# Altium schematic sheet P770010E05-Xx-SH-3_LNA.SchDoc(P770010E05-Xx-SH-3_LNA)
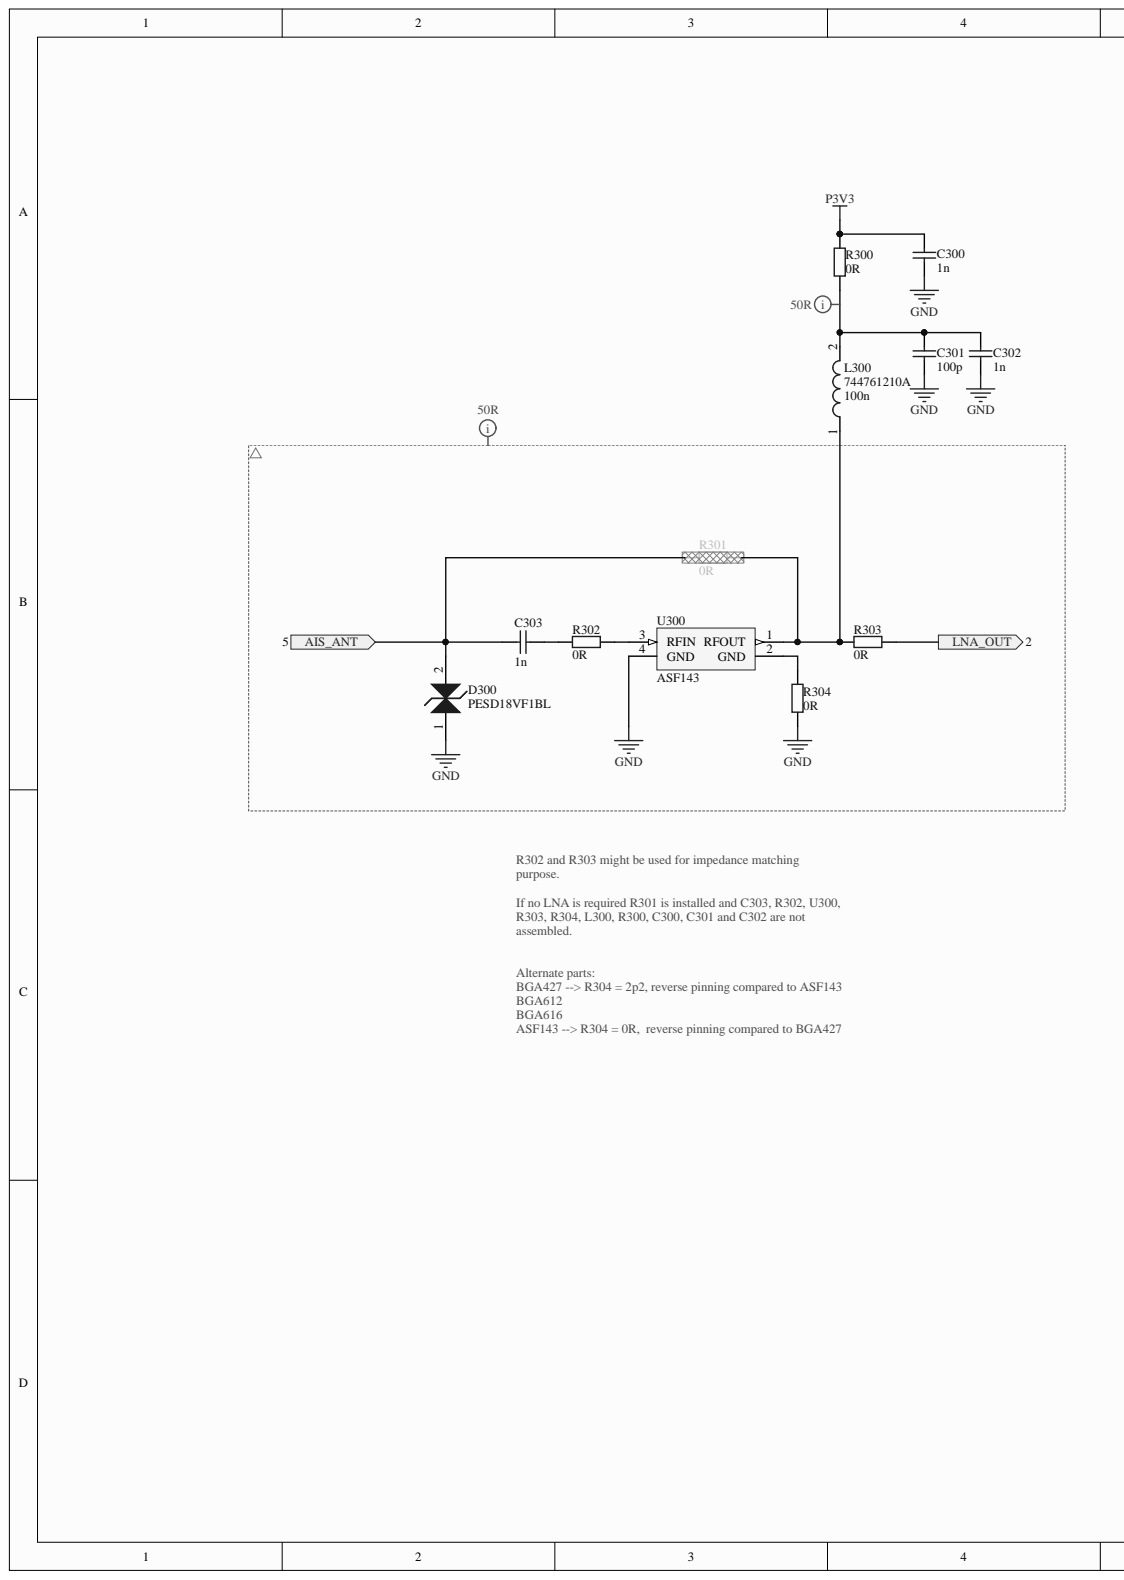
[diagram p0: P770010E05-Xx-SH-3_LNA.SchDoc, left]
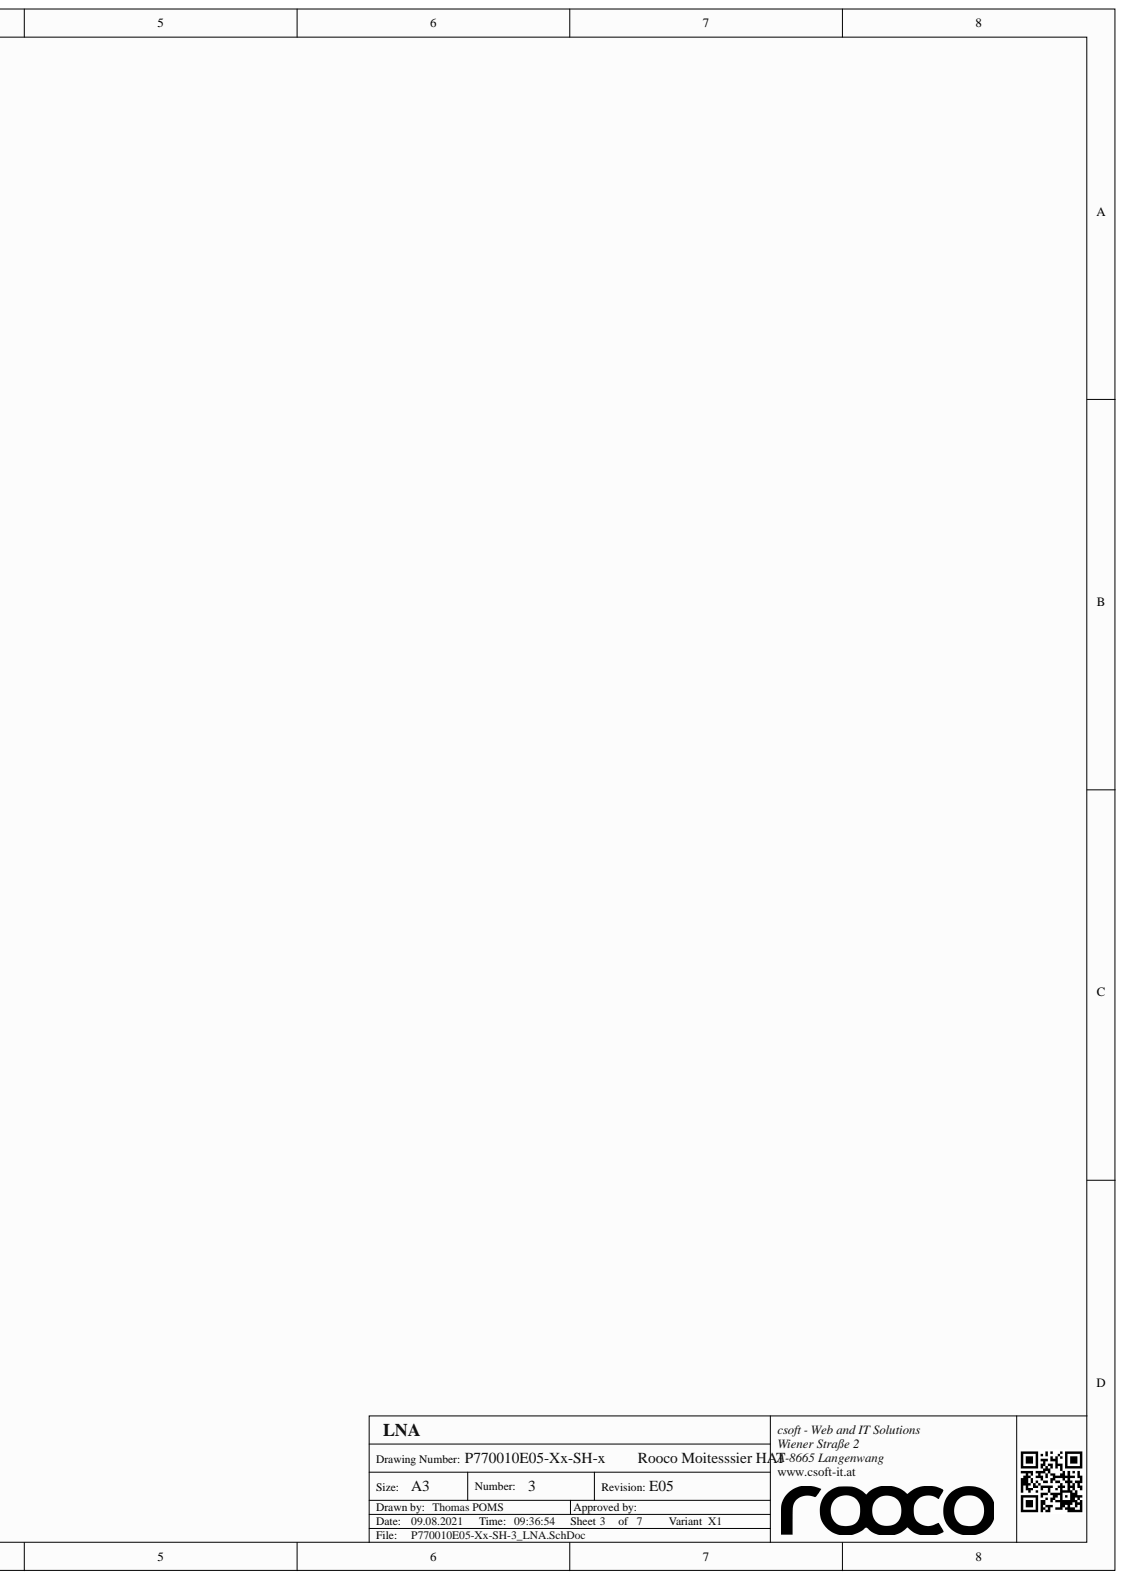
[diagram p1: P770010E05-Xx-SH-3_LNA.SchDoc, right]

## Parts
C300  1n  [C0402]
C301  100p  [C0402]
C302  1n  [C0402]
C303  1n  [C0402]
D300  PESD18VF1BL  [PESD18VF1BL_SOD-882]
L300  744761210A  value 100n  [744761210A_0603]
R300  0R  [R0805]
R301  0R  [R0402]
R302  0R  [R0402]
R303  0R  [R0402]
R304  0R  [R0402]
U300  ASF143  [ASF143_SOT-343]

## Ports
AIS_ANT, LNA_OUT

## Nets
GND: C300.1 C301.1 C302.1 D300.1 R304.1 U300.4(GND)
P3V3: C300.2 R300.2
AIS_ANT: C303.1 D300.2 R301.1 ; port AIS_ANT
LNA_OUT: R303.2 ; port LNA_OUT
NetC301_2: C301.2 C302.2 L300.2 R300.1
NetC303_2: C303.2 R302.1
NetL300_1: L300.1 R301.2 R303.1 U300.1(RFOUT)
NetR302_2: R302.2 U300.3(RFIN)
NetR304_2: R304.2 U300.2(GND)
N00085:

## Library data of the parts
C300 C302 C303 = C1005C0G1H102G050BA: ceramic chip capacitor, 1nF, 50V, NP0, 0402; Manufacturer: TDK; ID: 25; Obsolete: False; Published by: FT-TEC; RevisionHistory: [1.0]; ToleranceValue: +/-2%; Component Kind: Standard; ComponentLink1Description: Datasheet; ComponentLink1URL: http://www.tdk.com/pdf/tight_tol_B11.pdf; ComponentType: Standard; Database Table Name: Capacitor; Dielectric: NP0; Library Name: CompanyDatabase.DbLib; Package: 0402; Part Number: C1005C0G1H102G050BA; Pin Count: 2; Published: 26.02.2013; Revision: 1.0; TemperatureMax: 125°C; TemperatureMin: -55°C; Value: 1n; VoltageTypical: 50V; Checked by: Thomas POMS; Drawn by: Thomas POMS
C301 = UMK105CG101JV: ceramic chip capacitor, 100pF, 50V, C0G, 0402; Manufacturer: Taiyo Yuden; ID: 17; Obsolete: False; Published by: FT-TEC; RevisionHistory: [1.0]; ToleranceValue: +/-5%; Component Kind: Standard; ComponentLink1Description: Datasheet; ComponentLink1URL: http://media.digikey.com/pdf/Data%20Sheets/Taiyo%20Yuden%20PDFs%20URL%20links/Standard%20Multilayer%20Ceramic%20Caps.pdf; ComponentType: Standard; Database Table Name: Capacitor; Dielectric: C0G; Library Name: CompanyDatabase.DbLib; Package: 0402; Part Number: UMK105CG101JV; Pin Count: 2; Published: 27.02.2012; Revision: 1.0; TemperatureMax: 125°C; TemperatureMin: -55°C; Value: 100p; VoltageTypical: 50V; Checked by: Thomas POMS; Drawn by: Thomas POMS
D300 = PESD18VF1BL: ultra low capacitance bidrectional ESD protection diode; Manufacturer: NXP; ID: 24; Obsolete: False; Published by: FT-TEC; RevisionHistory: [1.0] Creation; Component Kind: Standard; ComponentLink1Description: Datasheet; ComponentLink1URL: http://www.nxp.com/documents/data_sheet/PESD18VF1BL.pdf; ComponentType: Standard; Database Table Name: Diode; Library Name: CompanyDatabase.DbLib; Package: SOD-882; Part Number: PESD18VF1BL; Pin Count: 2; Published: 22.07.2015; Revision: 1.0; TemperatureMax: 150°C; TemperatureMin: -55°C; Value: PESD18VF1BL; Checked by: Thomas POMS; Drawn by: Thomas POMS
L300 = 744761210A: ceramic chip inductor, 0603, 100 nH,  400 mA, 0.63 RDC; Manufacturer: Würth; CurrentRating: 400mA; ID: 19; Obsolete: False; Published by: FT-TEC; ResistanceDCmax: 0.63R; RevisionHistory: [1.0] Creation; Component Kind: Standard; ComponentLink1Description: Datasheet; ComponentLink1URL: http://katalog.we-online.de/pbs/datasheet/744761210A.pdf; ComponentType: Standard; Database Table Name: Inductor; Library Name: CompanyDatabase.DbLib; Package: 0603; Part Number: 744761210A; Pin Count: 2; Published: 08.07.2013; Revision: 1.0; TemperatureMax: 125°C; TemperatureMin: -40°C; Checked by: Thomas POMS; Drawn by: Thomas POMS
R300 = ERJ-6GEY0R00V: thick film resistor, 0R, 1%, 100ppm, 0805; Manufacturer: Panasonic; ID: 8; Obsolete: False; PowerRating: 125mW; Published by: FT-TEC; RevisionHistory: [1.0] Creation; ToleranceValue: 5%; VoltageRating: 150; Component Kind: Standard; ComponentLink1Description: Datasheet; ComponentLink1URL: http://industrial.panasonic.com/www-data/pdf/AOA0000/AOA0000CE1.pdf; ComponentType: Standard; Database Table Name: Resistor; Library Name: CompanyDatabase.DbLib; Package: 0805; Part Number: ERJ-6GEY0R00V; Pin Count: 2; Published: 24.02.2012; Revision: 1.0; TemperatureMax: 155°C; TemperatureMin: -55°C; Value: 0R; Checked by: Thomas POMS; Drawn by: Thomas Poms
R301-R304 = CRCW04020000Z0ED: thick film resistor, 0R, 1%, 100ppm, 0402; Manufacturer: Vishay; ID: 2; Obsolete: False; PowerRating: 63mW; Published by: FT-TEC; RevisionHistory: [1.0] Creation; VoltageRating: 50V; Component Kind: Standard; ComponentType: Standard; Database Table Name: Resistor; Library Name: CompanyDatabase.DbLib; Package: 0402; Part Number: CRCW04020000Z0ED; Pin Count: 2; Published: 16.02.2012; Revision: 1.0; TemperatureCoefficient: 100ppm; TemperatureMax: 155°C; TemperatureMin: -55°C; ToleranceValue: 1%; Value: 0R; Checked by: Thomas POMS; Drawn by: Thomas Poms
U300 = ASF143: IF gain block amplifier MMIC, DC-1000MHz; Manufacturer: ASB; Database Table Name: RF_Amplifier; ID: 5; Obsolete: False; Published by: FT-TEC; RevisionHistory: [1.0] Creation; Component Kind: Standard; ComponentLink1Description: Datasheet; ComponentLink1URL: http://www.compotek.de/fileadmin/Datenblaetter/ASB/ASF143.pdf; ComponentType: Standard; Library Name: CompanyDatabase.DbLib; Package: SOT-343; Part Number: ASF143; Pin Count: 4; Published: 13.03.2017; Revision: 1.0; TemperatureMax: 85°C; TemperatureMin: -40°C; Value: ASF143; Checked by: Thomas POMS; Drawn by: Thomas POMS
